# Altium schematic sheet horizontal_counter.SchDoc(horizontal_counter)
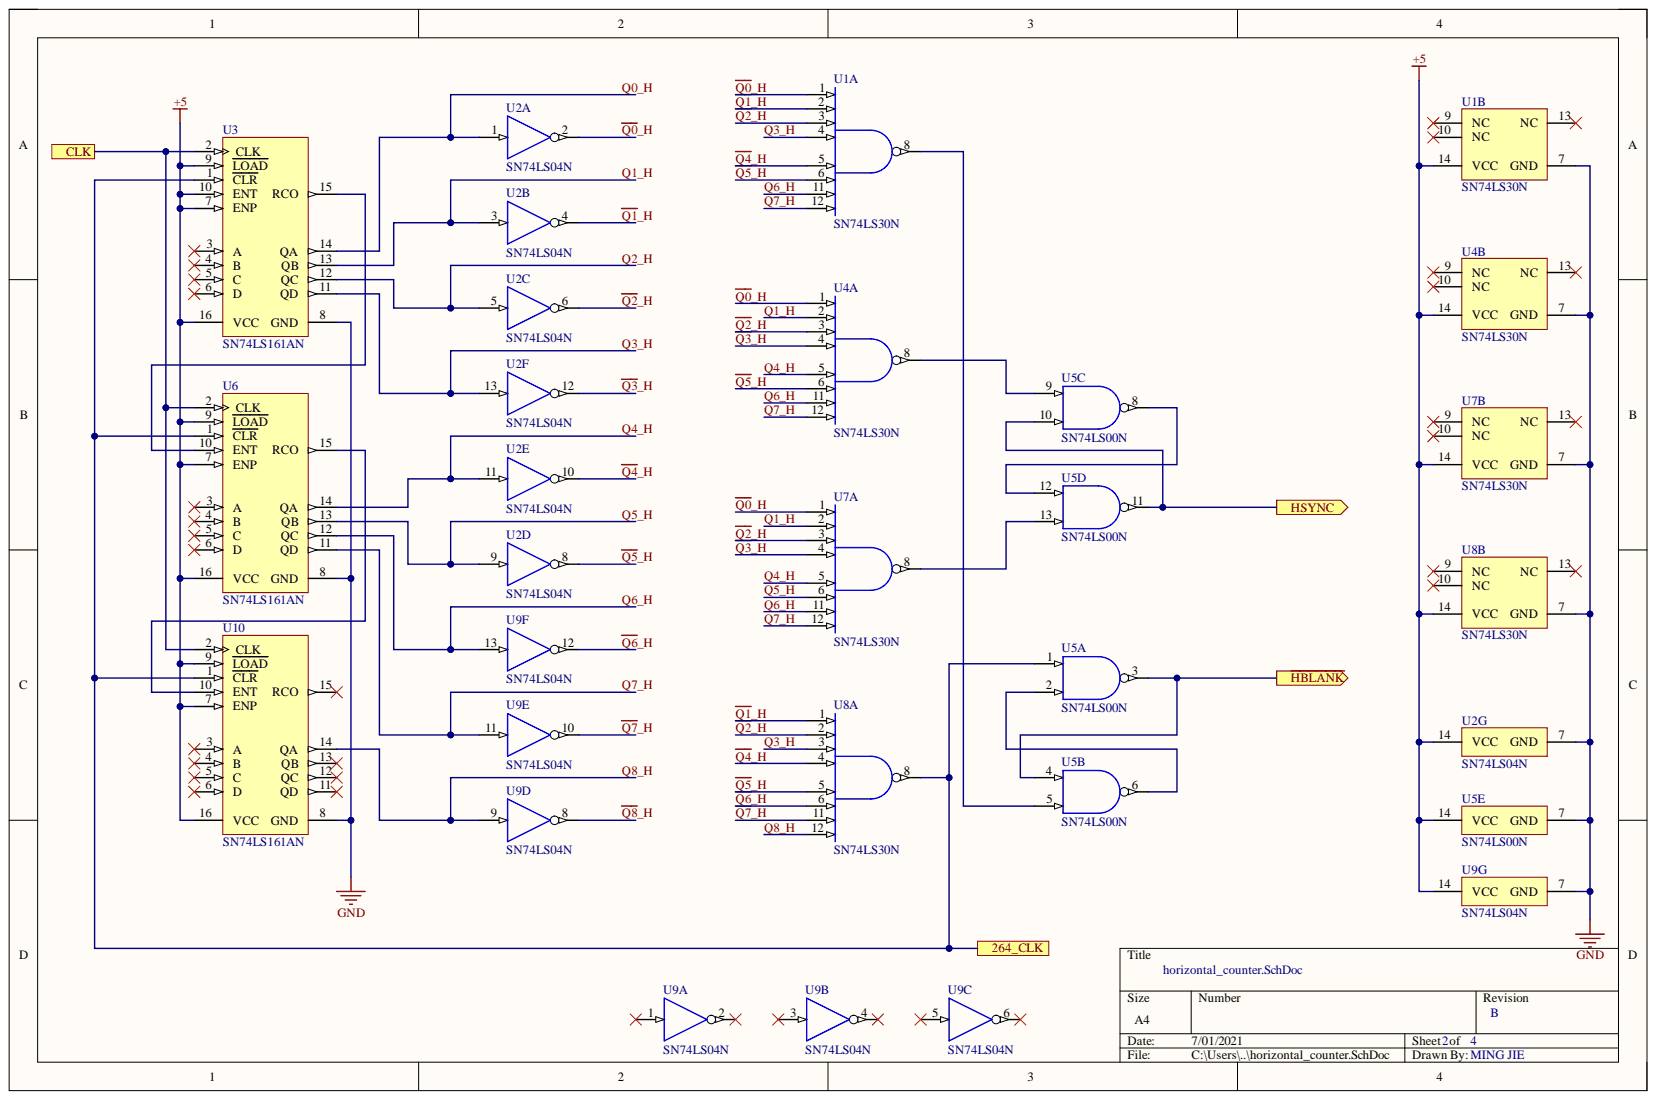
[diagram p0: horizontal_counter.SchDoc]

## Parts
U1  SN74LS30N  [N0014A]  (parts A,B)
U2  SN74LS04N  [N0014A]  (parts A,B,C,D,E,F,G)
U3  SN74LS161AN  [N0016A]
U4  SN74LS30N  [N0014A]  (parts A,B)
U5  SN74LS00N  [N0014A]  (parts A,B,C,D,E)
U6  SN74LS161AN  [N0016A]
U7  SN74LS30N  [N0014A]  (parts A,B)
U8  SN74LS30N  [N0014A]  (parts A,B)
U9  SN74LS04N  [N0014A]  (parts A,B,C,D,E,F,G)
U10  SN74LS161AN  [N0016A]

## Ports
264_CLK, CLK, HSYNC, ~{HBLANK}

## Nets
+5: U1.14(VCC) U2.14(VCC) U3.7(ENP) U3.9(LOAD) U3.10(ENT) U3.16(VCC) U4.14(VCC) U5.14(VCC) U6.7(ENP) U6.9(LOAD) U6.16(VCC) U7.14(VCC) U8.14(VCC) U9.14(VCC) U10.7(ENP) U10.9(LOAD) U10.16(VCC)
GND: U1.7(GND) U2.7(GND) U3.8(GND) U4.7(GND) U5.7(GND) U6.8(GND) U7.7(GND) U8.7(GND) U9.7(GND) U10.8(GND)
264_CLK: U3.1(CLR) U5.1 U6.1(CLR) U8.8 U10.1(CLR) ; port 264_CLK
CLK: U3.2(CLK) U6.2(CLK) U10.2(CLK) ; port CLK
~{HBLANK}: U5.3 U5.4 ; port ~{HBLANK}
HSYNC: U5.10 U5.11 ; port HSYNC
Q0_H: U2.1 U3.14(QA)
Q1_H: U2.3 U3.13(QB) U4.2 U7.2
Q2_H: U2.5 U3.12(QC)
Q3_H: U1.4 U2.13 U3.11(QD) U8.3
Q4_H: U2.11 U4.5 U6.14(QA) U7.5
Q5_H: U2.9 U6.13(QB) U7.6
Q6_H: U1.11 U4.11 U6.12(QC) U7.11 U9.13
Q7_H: U1.12 U4.12 U6.11(QD) U7.12 U9.11
Q8_H: U8.12 U9.9 U10.14(QA)
~{Q0}_H: U1.1 U2.2 U4.1 U7.1
~{Q1}_H: U1.2 U2.4 U8.1
~{Q2}_H: U1.3 U2.6 U4.3 U7.3 U8.2
~{Q3}_H: U2.12 U4.4 U7.4
~{Q4}_H: U1.5 U2.10 U8.4
~{Q5}_H: U1.6 U2.8 U4.6 U8.5
~{Q6}_H: U8.6 U9.12
~{Q7}_H: U8.11 U9.10
~{Q8}_H: U9.8
NetU1_8: U1.8 U5.5
NetU3_15: U3.15(RCO) U6.10(ENT)
NetU4_8: U4.8 U5.9
NetU5_2: U5.2 U5.6
NetU5_8: U5.8 U5.12
NetU5_13: U5.13 U7.8
NetU6_15: U6.15(RCO) U10.10(ENT)

Not connected: U1 9(NC) 10(NC) 13(NC); U3 3(A) 4(B) 5(C) 6(D); U4 9(NC) 10(NC) 13(NC); U6 3(A) 4(B) 5(C) 6(D); U7 9(NC) 10(NC) 13(NC); U8 9(NC) 10(NC) 13(NC); U9 1 2 3 4 5 6; U10 3(A) 4(B) 5(C) 6(D) 11(QD) 12(QC) 13(QB) 15(RCO)

## Library data of the parts
U1 U4 U7 U8 = CMP-1627-00459-2: 8-input positive-NAND gates, N0014A, TUBE; Manufacturer: Texas Instruments; Bits(#): 1; F @ Nom Voltage(Max)(Mhz): 35; ICC @ Nom Voltage(Max)(mA): 0.006; Input Type: TTL; Output Drive (IOL/IOH)(Max)(mA): 0.4/-16; Rating: Catalog; Schmitt Trigger: No; Technology Family: LS; tpd @ Nom Voltage(Max)(ns): 22; VCC(Max)(V): 5.25; VCC(Min)(V): 4.75; Voltage(Nom)(V): 5; Code_JEDEC: MS-001; Component Kind: Standard; DatasheetVersion: SDLS099; Generic Part Number: SN74LS30; Library Name: Altium Content Vault; Operating Temperature Range(C): 0 to 70; Output Type: TTL; PackageReference: N0014A
U2 U9 = CMP-1615-00911-2: Hex inverters, N0014A, TUBE; Manufacturer: Texas Instruments; Bits(#): 6; F @ Nom Voltage(Max)(Mhz): 35; ICC @ Nom Voltage(Max)(mA): 0.033; Input Type: TTL; Output Drive (IOL/IOH)(Max)(mA): -0.4/8; Output Type: CMOS; Rating: Catalog; Schmitt Trigger: No; Technology Family: LS; tpd @ Nom Voltage(Max)(ns): 22; VCC(Max)(V): 5.25; VCC(Min)(V): 4.75; Voltage(Nom)(V): 5; Code_JEDEC: MS-001; Component Kind: Standard; DatasheetVersion: SDLS029C; Generic Part Number: SN74LS04; Library Name: Altium Content Vault; Operating Temperature Range(C): 0 to 70; PackageReference: N0014A
U3 U6 U10 = CMP-1623-00512-2: Synchronous 4-Bit Binary Counters, N0016A, TUBE; Manufacturer: Texas Instruments; Bits(#): 4; F @ Nom Voltage(Max)(Mhz): 35; ICC @ Nom Voltage(Max)(mA): 32; Input Type: TTL; Inputs: 1; Output Drive (IOL/IOH)(Max)(mA): 8/-0.4; Output Type: CMOS; Rating: Catalog; Schmitt Trigger: No; Technology Family: LS; VCC(Max)(V): 5.25; VCC(Min)(V): 4.75; Voltage(Nom)(V): 5; Code_JEDEC: MS-001; Component Kind: Standard; DatasheetVersion: SDLS060; Function: Counter; Generic Part Number: SN74LS161A; Library Name: Altium Content Vault; Operating Temperature Range(C): 0 to 70; PackageReference: N0016A; tpd @ Nom Voltage(Max)(ns): 35; Type: Binary
U5 = CMP-1627-00476-2: Quad 2-input positive-NAND gates, N0014A, TUBE; Manufacturer: Texas Instruments; Bits(#): 4; F @ Nom Voltage(Max)(Mhz): 35; ICC @ Nom Voltage(Max)(mA): 0.022; Input Type: TTL; Output Drive (IOL/IOH)(Max)(mA): 0.4/-16; Rating: Catalog; Schmitt Trigger: No; Technology Family: LS; tpd @ Nom Voltage(Max)(ns): 22; VCC(Max)(V): 5.25; VCC(Min)(V): 4.75; Voltage(Nom)(V): 5; Code_JEDEC: MS-001; Component Kind: Standard; DatasheetVersion: SDLS025B; Generic Part Number: SN74LS00; Library Name: Altium Content Vault; Operating Temperature Range(C): 0 to 70; Output Type: TTL; PackageReference: N0014A
